# Altium schematic sheet 2.STM32F103C8T6.SchDoc(2.STM32F103C8T6)
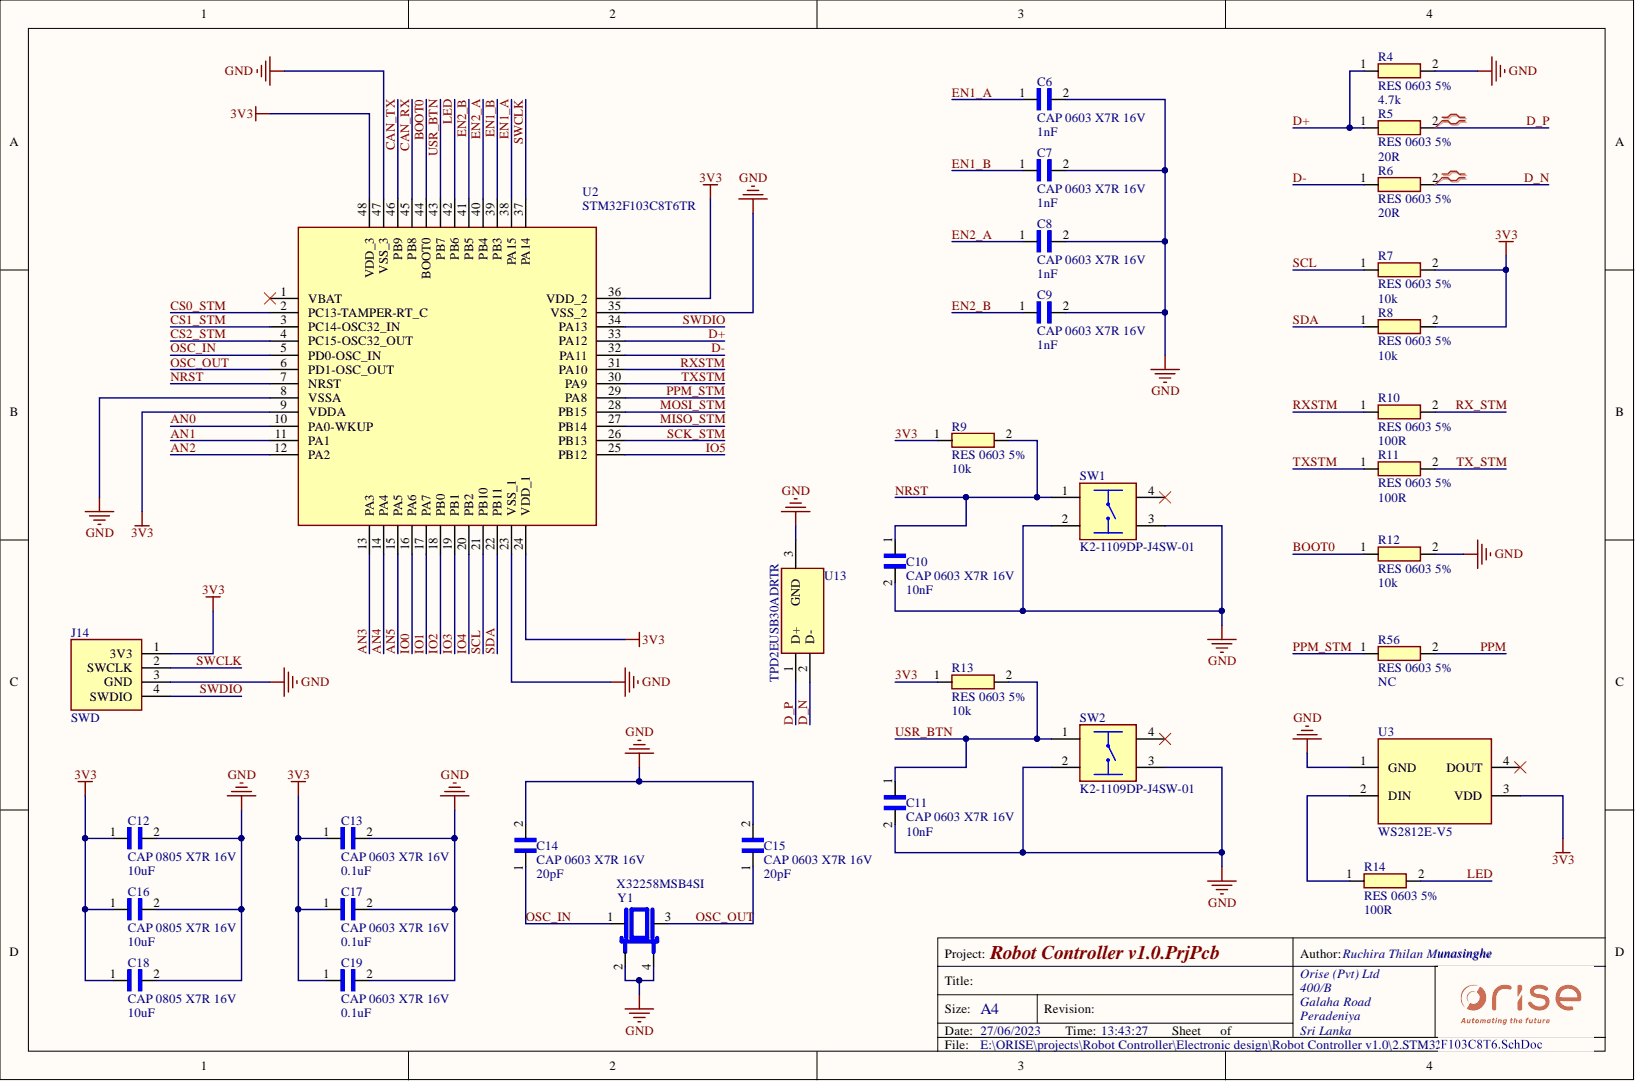
[diagram p0: 2.STM32F103C8T6.SchDoc]

## Parts
C6  CAP 0603 X7R 16V  [CAP 0603]
C7  CAP 0603 X7R 16V  value 1nF  [CAP 0603]
C8  CAP 0603 X7R 16V  value 1nF  [CAP 0603]
C9  CAP 0603 X7R 16V  value 1nF  [CAP 0603]
C10  CAP 0603 X7R 16V  value 10nF  [CAP 0603]
C11  CAP 0603 X7R 16V  value 10nF  [CAP 0603]
C12  CAP 0805 X7R 16V  [CAP 0805]
C13  CAP 0603 X7R 16V  [CAP 0603]
C14  CAP 0603 X7R 16V  value 20pF  [CAP 0603]
C15  CAP 0603 X7R 16V  value 20pF  [CAP 0603]
C16  CAP 0805 X7R 16V  value 10uF  [CAP 0805]
C17  CAP 0603 X7R 16V  value 0.1uF  [CAP 0603]
C18  CAP 0805 X7R 16V  value 10uF  [CAP 0805]
C19  CAP 0603 X7R 16V  value 0.1uF  [CAP 0603]
J14  SWD  [4PIN]
R4  RES 0603 5%  value 4.7k  [RES 0603]
R5  RES 0603 5%  value 20R  [RES 0603]
R6  RES 0603 5%  value 20R  [RES 0603]
R7  RES 0603 5%  value 10k  [RES 0603]
R8  RES 0603 5%  value 10k  [RES 0603]
R9  RES 0603 5%  value 10k  [RES 0603]
R10  RES 0603 5%  value 100R  [RES 0603]
R11  RES 0603 5%  value 100R  [RES 0603]
R12  RES 0603 5%  value 10k  [RES 0603]
R13  RES 0603 5%  value 10k  [RES 0603]
R14  RES 0603 5%  value 100R  [RES 0603]
R56  RES 0603 5%  value NC  [RES 0603 NC]
SW1  K2-1109DP-J4SW-01  [K21109DPB4SW01]
SW2  K2-1109DP-J4SW-01  [K21109DPB4SW01]
U2  STM32F103C8T6TR  [STM32F103C8T6]
U3  WS2812E-V5  [WS2812E-V5]
U13  TPD2EUSB30ADRTR  [SOT35P100X50-3N]
Y1  X32258MSB4SI  [X32258MSB4SI]

## Nets
GND: C6.2 C7.2 C8.2 C9.2 C10.2 C11.2 C12.2 C13.2 C14.2 C15.2 C16.2 C17.2 C18.2 C19.2 J14.3(GND) R4.2 R12.2 SW1.2 SW1.3 SW2.2 SW2.3 U2.8(VSSA) U2.23(VSS_1) U2.35(VSS_2) U2.47(VSS_3) U3.1(GND) U13.3 Y1.2 Y1.4
3V3: C12.1 C13.1 C16.1 C17.1 C18.1 C19.1 J14.1(3V3) R7.2 R8.2 R9.1 R13.1 U2.9(VDDA) U2.24(VDD_1) U2.36(VDD_2) U2.48(VDD_3) U3.3(VDD)
AN0: U2.10(PA0-WKUP)
AN1: U2.11(PA1)
AN2: U2.12(PA2)
AN3: U2.13(PA3)
AN4: U2.14(PA4)
AN5: U2.15(PA5)
BOOT0: R12.1 U2.44(BOOT0)
CAN_RX: U2.45(PB8)
CAN_TX: U2.46(PB9)
CS0_STM: U2.2(PC13-TAMPER-RT_C)
CS1_STM: U2.3(PC14-OSC32_IN)
CS2_STM: U2.4(PC15-OSC32_OUT)
D+: R4.1 R5.1 U2.33(PA12)
D-: R6.1 U2.32(PA11)
D_N: R6.2 U13.2
D_P: R5.2 U13.1
EN1_A: C6.1 U2.38(PA15)
EN1_B: C7.1 U2.39(PB3)
EN2_A: C8.1 U2.40(PB4)
EN2_B: C9.1 U2.41(PB5)
IO0: U2.16(PA6)
IO1: U2.17(PA7)
IO2: U2.18(PB0)
IO3: U2.19(PB1)
IO4: U2.20(PB2)
IO5: U2.25(PB12)
LED: R14.2 U2.42(PB6)
MISO_STM: U2.27(PB14)
MOSI_STM: U2.28(PB15)
NRST: C10.1 R9.2 SW1.1 U2.7(NRST)
OSC_IN: C14.1 U2.5(PD0-OSC_IN) Y1.1
OSC_OUT: C15.1 U2.6(PD1-OSC_OUT) Y1.3
PPM: R56.2
PPM_STM: R56.1 U2.29(PA8)
RX_STM: R10.2
RXSTM: R10.1 U2.31(PA10)
SCK_STM: U2.26(PB13)
SCL: R7.1 U2.21(PB10)
SDA: R8.1 U2.22(PB11)
SWCLK: J14.2(SWCLK) U2.37(PA14)
SWDIO: J14.4(SWDIO) U2.34(PA13)
TX_STM: R11.2
TXSTM: R11.1 U2.30(PA9)
USR_BTN: C11.1 R13.2 SW2.1 U2.43(PB7)
NetR14_1: R14.1 U3.2(DIN)

Not connected: SW1 4; SW2 4; U2 1(VBAT); U3 4(DOUT)

## Library data of the parts
C6 = CAP 0603 X7R 16V: Value: 1nF; Library Name: Robot Controller v1.0.SchLib; Description: CAP 0603 X7R 16V; Component Kind: Standard
C12 = CAP 0805 X7R 16V: Value: 10uF; Library Name: Robot Controller v1.0.SchLib; Description: CAP 0805 X7R 16V; Component Kind: Standard
C13 = CAP 0603 X7R 16V: Value: 0.1uF; Library Name: Robot Controller v1.0.SchLib; Description: CAP 0603 X7R 16V; Component Kind: Standard
J14 = SWD: Serial wire debugger; Manufacturer_Part_Number: 07-0600-10; Manufacturer_Name: ARIES; Mouser Price/Stock: https://www.mouser.com/Search/Refine.aspx?Keyword=535-07-0600-10; Mouser Part Number: 535-07-0600-10; Library Name: Robot Controller v1.0.SchLib; Height: 5.71mm; Datasheet Link: https://www.arieselec.com/products/data/12034-strip-line-header.pdf; Component Kind: Standard
R4 = RES 0603 5%: value: 4.7k; Library Name: Robot Controller v1.0.SchLib; Description: RES 0603 5%; Component Kind: Standard
R5 = RES 0603 5%: value: 20R; Library Name: Robot Controller v1.0.SchLib; Description: RES 0603 5%; Component Kind: Standard
R7 = RES 0603 5%: value: 10k; Library Name: Robot Controller v1.0.SchLib; Description: RES 0603 5%; Component Kind: Standard
R10 = RES 0603 5%: value: 100R; Library Name: Robot Controller v1.0.SchLib; Description: RES 0603 5%; Component Kind: Standard
R56 = RES 0603 5% NC: Library Name: Robot Controller v1.0.SchLib; Description: RES 0603 5%; Component Kind: Standard
SW1 SW2 = K2-1109DP-J4SW-01: -25℃~+85℃ 4.5mm 8mm Round Button 50mA Straight 4.5mm SPST 100MΩ 100000 250gf@±30gf 12V Plugin  Tactile Switches ROHS; Manufacturer_Name: Korean Hroparts Elec; Manufacturer_Part_Number: K2-1109DP-J4SW-01; Library Name: Robot Controller v1.0.SchLib; LCSC Part Number: C223803; Component Kind: Standard
U2 = STM32F103C8T6TR: ARM® Cortex®-M3 series Microcontroller IC 32-Bit Single-Core 72MHz 64KB (64K x 8) FLASH 48-LQFP (7x7); Manufacturer_Name: STMicroelectronics; Manufacturer_Part_Number: STM32F103C8T6TR; Library Name: Robot Controller v1.0.SchLib; Component Kind: Standard
U3 = WS2812E-V5: 12.5mA RGB 5050(mm)  Light Emitting Diodes (LED) ROHS; Manufacturer: Worldsemi; Manufacturer_Part_Number: WS2812E-V5; Library Name: Robot Controller v1.0.SchLib; LCSC Part Number: C2920042; Component Kind: Standard
U13 = TPD2EUSB30ADRTR: SOT-9x3 ESD Protection Devices ROHS; Manufacturer_Name: TECH PUBLIC; Manufacturer_Part_Number: TPD2EUSB30ADRTR; Library Name: Robot Controller v1.0.SchLib; LCSC Part Number: C3011197; Component Kind: Standard
Y1 = X32258MSB4SI: 8MHz SMD Crystal Resonator 20pF ±10ppm ±30ppm -40℃~+85℃ SMD3225-4P  Crystals ROHS; Manufacturer: Yangxing Tech; Manufacturer Part Number: X32258MSB4SI; Library Name: Robot Controller v1.0.SchLib; LCSC Part Number: C2682774; Component Kind: Standard
C7-C11 C14 C15 C17 C19 = CAP 0603 X7R 16V: Library Name: Robot Controller v1.0.SchLib; Description: CAP 0603 X7R 16V; Component Kind: Standard
C16 C18 = CAP 0805 X7R 16V: Library Name: Robot Controller v1.0.SchLib; Description: CAP 0805 X7R 16V; Component Kind: Standard
R6 R8 R9 R11-R14 = RES 0603 5%: Library Name: Robot Controller v1.0.SchLib; Description: RES 0603 5%; Component Kind: Standard
